# Altium schematic sheet Ethernet.SchDoc(Ethernet)
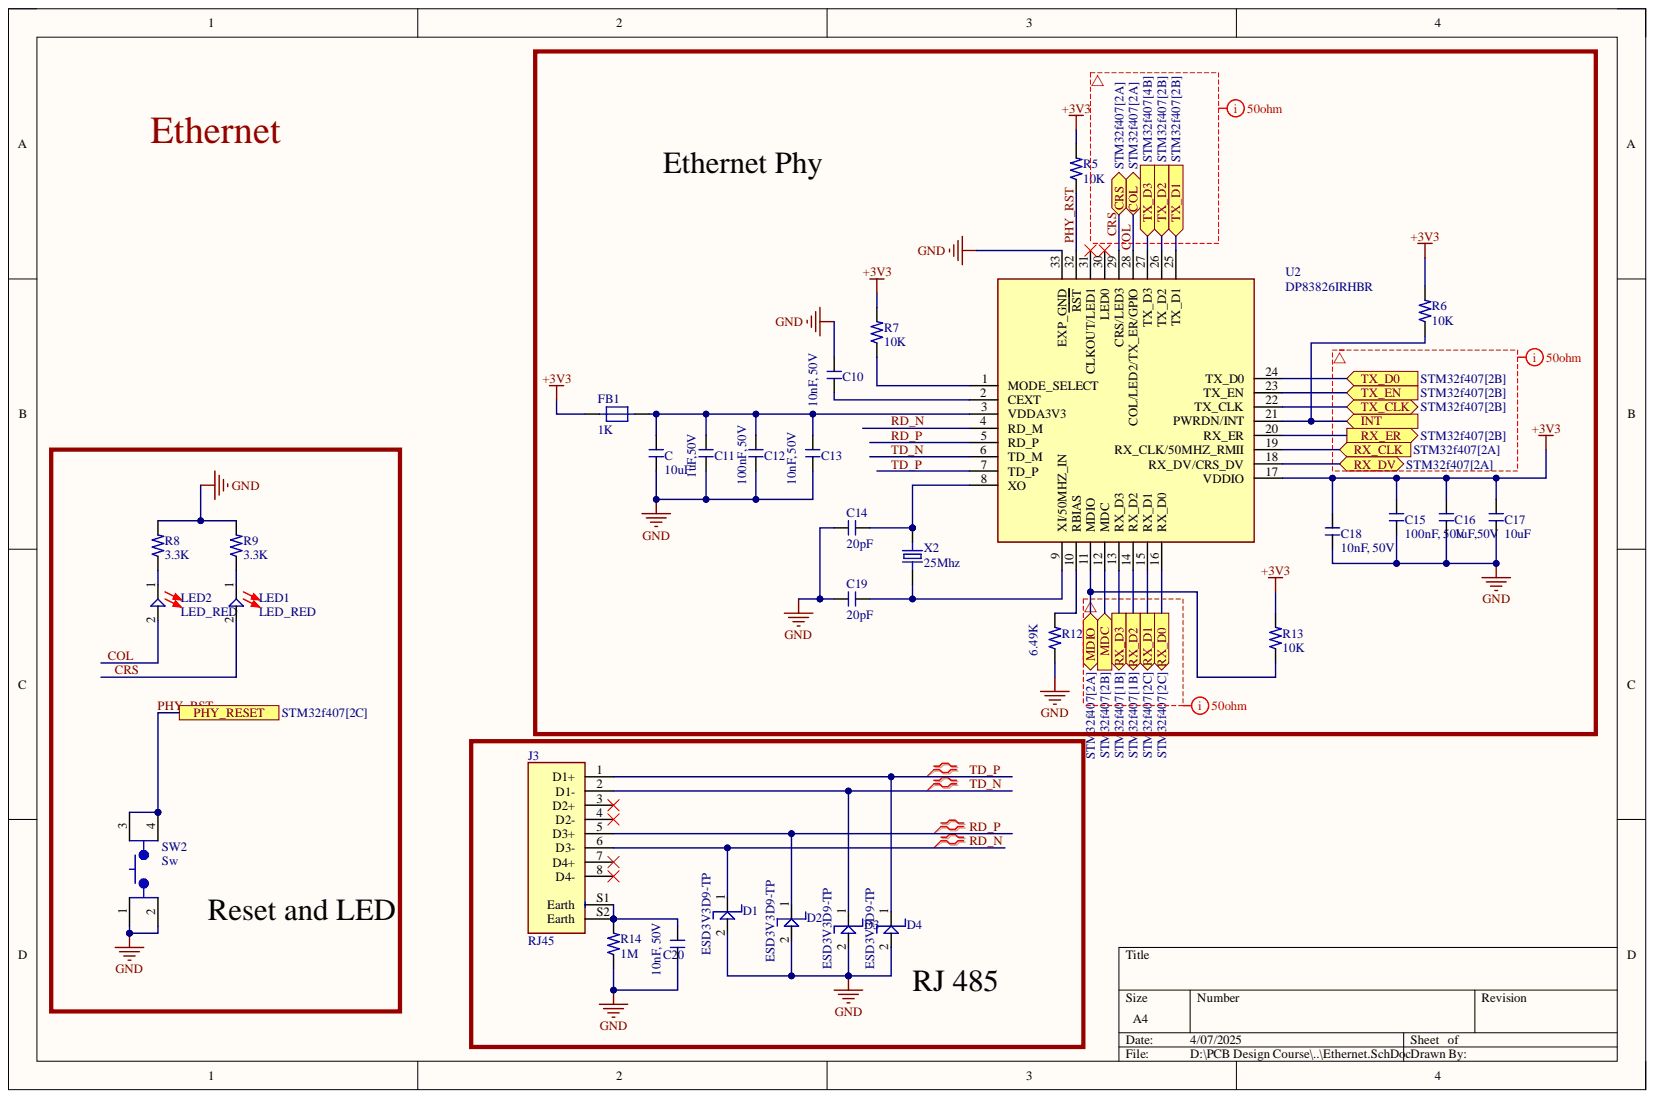
[diagram p0: Ethernet.SchDoc]

## Parts
C  10uF  [Capacitor_1206_SMD]
C10  10nF, 50V  Voltage Rating (DC): 50V  [Capacitor_0805]
C11  1uF,50V  [Capacitor_0805]
C12  100nF, 50V  Voltage: 50V  [Capacitor_0805]
C13  10nF, 50V  Voltage Rating (DC): 50V  [Capacitor_0805]
C14  20pF  [Capacitor_0805]
C15  100nF, 50V  Voltage: 50V  [Capacitor_0805]
C16  1uF,50V  Voltage Rating (DC): 50V  [Capacitor_0805]
C17  10uF  [Capacitor_1206_SMD]
C18  10nF, 50V  [Capacitor_0805]
C19  20pF  [Capacitor_0805]
C20  10nF, 50V  Voltage Rating (DC): 50V  [Capacitor_0805]
D1  ESD3V3D9-TP  [SOD_923]
D2  ESD3V3D9-TP  [SOD_923]
D3  ESD3V3D9-TP  [SOD_923]
D4  ESD3V3D9-TP  [SOD_923]
FB1  1K  [FB_0805]
J3  RJ45  [RJ45_Right_Angle_90_degree]
LED1  LED_RED  [LTST-C171KRKT]
LED2  LED_RED  [LTST-C171KRKT]
R5  10K  [Resistor_0603]
R6  10K  [Resistor_0603]
R7  10K  [Resistor_0603]
R8  3.3K  [Resistor_0603]
R9  3.3K  [Resistor_0603]
R12  6.49K  [Resistor_0805]
R13  10K  [Resistor_0603]
R14  1M  [Resistor_1206_SMD]
SW2  Sw  [SW_Tactile_SMD]
U2  DP83826IRHBR  [32-VQFN (5x5)]
X2  25Mhz  [Xtal_314_240X47_SMD]

## Ports
COL, CRS, INT, MDC, MDIO, PHY_RESET, RX_CLK, RX_D0, RX_D1, RX_D2, RX_D3, RX_DV, RX_ER, TX_CLK, TX_D0, TX_D1, TX_D2, TX_D3, TX_EN

## Nets
+3V3: C15.2 C16.2 C17.2 C18.2 FB1.1 R5.2 R6.2 R7.2 R13.2 U2.17(VDDIO)
GND: C.1 C10.2 C11.1 C12.1 C13.1 C14.1 C15.1 C16.1 C17.1 C18.1 C19.1 C20.1 D1.2 D2.2 D3.2 D4.2 R8.2 R9.2 R12.1 R14.1 SW2.1 SW2.2 U2.33(EXP_GND)
COL: LED2.2 U2.28(COL/LED2/TX_ER/GPIO) ; port COL
CRS: LED1.2 U2.29(CRS/LED3) ; port CRS
INT: R6.1 U2.21(PWRDN/INT) ; port INT
MDC: U2.12(MDC) ; port MDC
MDIO: R13.1 U2.11(MDIO) ; port MDIO
PHY_RST: R5.1 SW2.3 SW2.4 U2.32(RST) ; port PHY_RESET
RD_N: D1.1 J3.6(D3-) U2.4(RD_M)
RD_P: D2.1 J3.5(D3+) U2.5(RD_P)
RX_CLK: U2.19(RX_CLK/50MHZ_RMII) ; port RX_CLK
RX_D0: U2.16(RX_D0) ; port RX_D0
RX_D1: U2.15(RX_D1) ; port RX_D1
RX_D2: U2.14(RX_D2) ; port RX_D2
RX_D3: U2.13(RX_D3) ; port RX_D3
RX_DV: U2.18(RX_DV/CRS_DV) ; port RX_DV
RX_ER: U2.20(RX_ER) ; port RX_ER
TD_N: D3.1 J3.2(D1-) U2.6(TD_M)
TD_P: D4.1 J3.1(D1+) U2.7(TD_P)
TX_CLK: U2.22(TX_CLK) ; port TX_CLK
TX_D0: U2.24(TX_D0) ; port TX_D0
TX_D1: U2.25(TX_D1) ; port TX_D1
TX_D2: U2.26(TX_D2) ; port TX_D2
TX_D3: U2.27(TX_D3) ; port TX_D3
TX_EN: U2.23(TX_EN) ; port TX_EN
NetC10_1: C10.1 U2.2(CEXT)
NetC11_2: C.2 C11.2 C12.2 C13.2 FB1.2 U2.3(VDDA3V3)
NetC14_2: C14.2 U2.8(XO) X2.2
NetC19_2: C19.2 U2.9(XI/50MHZ_IN) X2.1
NetC20_2: C20.2 J3.S1(Earth) J3.S2(Earth) R14.2
NetLED1_1: LED1.1 R9.1
NetLED2_1: LED2.1 R8.1
NetR7_1: R7.1 U2.1(MODE_SELECT)
NetR12_2: R12.2 U2.10(RBIAS)

Not connected: J3 3(D2+) 4(D2-) 7(D4+) 8(D4-); U2 30(LED0) 31(CLKOUT/LED1)

## Library data of the parts
C C17 = Cap_10uF_1206: Multilayer Ceramic Capacitor, 10 uF, 50 V, ï¿½ 10%, X7R, 1206 [3216 Metric]; Manufacturer: Samsung; Manufacturer Part Number: CL31B106KBHNNNE; Voltage Rating: 50V; Case Code (Imperial): 1206; Case Code (Metric): 3216; Case/Package: 1206; Category: Ceramic Capacitors; Component Kind: Standard; ComponentLink1Description: https://datasheet.octopart.com/CL31B106KBHNNNE-Samsung-Electro-Mechanics-datasheet-149066874.pdf?src-supplier=Future+Electronics; ComponentLink1URL: https://datasheet.octopart.com/CL31B106KBHNNNE-Samsung-Electro-Mechanics-datasheet-149066874.pdf?src-supplier=Future+Electronics; ComponentLink2Description: http://www.samsungsem.com/kr/support/product-search/mlcc/CL31B106KBHNNNE.jsp?src-supplier=Digikey; ComponentLink2URL: http://www.samsungsem.com/kr/support/product-search/mlcc/CL31B106KBHNNNE.jsp?src-supplier=Digikey; ComponentLink3Description: https://datasheet.octopart.com/CL31B106KBHNNNE-Samsung-Electro-Mechanics-datasheet-137256395.pdf?src-supplier=Farnell; ComponentLink3URL: https://datasheet.octopart.com/CL31B106KBHNNNE-Samsung-Electro-Mechanics-datasheet-137256395.pdf?src-supplier=Farnell; ComponentLink4Description: https://datasheet.octopart.com/CL31B106KBHNNNE-Samsung-Electro-Mechanics-datasheet-110617700.pdf?src-supplier=LCSC; ComponentLink4URL: https://datasheet.octopart.com/CL31B106KBHNNNE-Samsung-Electro-Mechanics-datasheet-110617700.pdf?src-supplier=LCSC; Dielectric: X7R; Height: 1.8mm; Lead Free: Lead Free; Material: Ceramic; Max Operating Temperature: 125°C; Min Operating Temperature: -55°C; Mounting Technology: Surface Mount; Packaging: Cut Tape; Pricing: 1=0,25, 10=0,15, 100=0,1008, 1000=0,0728 (USD); RoHS: Yes; RoHS Compliant: Yes; Series: CL; Stock: 207136; Supplier: Digikey; Supplier Part Number: 1276-6767-1-ND; Tolerance: 10%; Value: 10uF; Voltage Rating (DC): 50V; Library Name: Schematics_Library_Hanif.SchLib
C10 C13 C18 C20 = Cap_10nF_0805: Multilayer Ceramic Capacitors MLCC - SMD/SMT 50V 0.01uF X7R 0805 10% AEC-Q200; Manufacturer: KEMET; Manufacturer Part Number: C0805C103K5RECAUTO; Dielectric: 1511DielectricType; Category: Ceramic Capacitors; Component Kind: Standard; ComponentLink1Description: http://www.farnell.com/datasheets/2723804.pdf?src-supplier=Farnell; ComponentLink1URL: http://www.farnell.com/datasheets/2723804.pdf?src-supplier=Farnell; ComponentLink2Description: https://api.kemet.com/component-edge/download/datasheet/C0805C103K5RECAUTO.pdf?src-supplier=Digikey; ComponentLink2URL: https://api.kemet.com/component-edge/download/datasheet/C0805C103K5RECAUTO.pdf?src-supplier=Digikey; ComponentLink3Description: http://www.farnell.com/datasheets/2723804.pdf?src-supplier=Newark; ComponentLink3URL: http://www.farnell.com/datasheets/2723804.pdf?src-supplier=Newark; Pricing: 1=0,22, 10=0,0376 (USD); RoHS: Yes; Stock: 16; Supplier: Arrow Electronics; Supplier Part Number: C0805C103K5RECAUTO; Library Name: Schematics_Library_Hanif.SchLib
  C18: Voltage Rating (DC): 50V
C11 C16 = Cap_1uF_0805: 0805 1 uF 50 V ±5 % Tolerance X7R Surface Mount Multilayer Ceramic Capacitor; Manufacturer: Yageo; Manufacturer Part Number: CC0805JKX7R9BB105; Dielectric: 1511DielectricType; Material: 4241ConductorMaterial; Category: Ceramic Capacitors; Component Kind: Standard; ComponentLink1Description: https://datasheet.octopart.com/CC0805JKX7R9BB105-Yageo-datasheet-137700082.pdf?src-supplier=Future+Electronics; ComponentLink1URL: https://datasheet.octopart.com/CC0805JKX7R9BB105-Yageo-datasheet-137700082.pdf?src-supplier=Future+Electronics; ComponentLink2Description: http://www.yageo.com/documents/recent/UPY-GPHC_X7R_6.3V-to-50V_18.pdf?src-supplier=Digikey; ComponentLink2URL: http://www.yageo.com/documents/recent/UPY-GPHC_X7R_6.3V-to-50V_18.pdf?src-supplier=Digikey; ComponentLink3Description: https://datasheet.octopart.com/CC0805JKX7R9BB105-Yageo-datasheet-151948686.pdf?src-supplier=Farnell; ComponentLink3URL: https://datasheet.octopart.com/CC0805JKX7R9BB105-Yageo-datasheet-151948686.pdf?src-supplier=Farnell; Halogen Free: 5694HalogenFree; Height: 1.45mm; Lead Free: 5697LeadFree; Pricing: 1=0,29, 10=0,168, 100=0,1077, 1000=0,07673 (USD); RoHS: Yes; Stock: 30160; Supplier: Digikey; Supplier Part Number: 311-3498-1-ND; Library Name: Schematics_Library_Hanif.SchLib
  C11: Voltage Rating (DC): 50V
C12 C15 = Cap_100nF_0805: Multilayer Ceramic Capacitor, 0.1 uF, 50 V, ï¿½ 10%, X7R, 0805 [2012 Metric]; Manufacturer: Samsung; Manufacturer Part Number: CL21B104KBCNNNC; Case Code (Imperial): 0805; Case Code (Metric): 2012; Case/Package: 0805; Category: Ceramic Capacitors; Component Kind: Standard; ComponentLink1Description: https://datasheet.ciiva.com/25710/cl21b104kbcnnnc-25710182.pdf?src-supplier=Digikey; ComponentLink1URL: https://datasheet.ciiva.com/25710/cl21b104kbcnnnc-25710182.pdf?src-supplier=Digikey; ComponentLink2Description: https://datasheet.ciiva.com/29199/454152-da-01-en-vs-kondensator-0805-29199814.pdf?src-supplier=Conrad; ComponentLink2URL: https://datasheet.ciiva.com/29199/454152-da-01-en-vs-kondensator-0805-29199814.pdf?src-supplier=Conrad; Construction: Flat; Depth: 1.25mm; Dielectric: X7R; Height: 0.85mm; Lead Free: 5697LeadFree; Length: 2mm; Material: Ceramic; Max Operating Temperature: 125°C; Min Operating Temperature: -55°C; Mounting Technology: Surface Mount; Packaging: Tape and Reel; Pricing: 1=0,08, 10=0,012, 100=0,0104, 1000=0,008 (USD); Radiation Hardening: No; RoHS: Yes; RoHS Compliant: Yes; Series: CL; Stock: 674609; Supplier: Digikey; Supplier Part Number: 1276-1003-1-ND; Thickness: 0.037inch; Tolerance: 10%; Value: 100nF; Voltage Rating: 50V; Voltage Rating (DC): 50V; Width: 0.049inch; Library Name: Schematics_Library_Hanif.SchLib
C14 C19 = Cap_20pF_0805: Cap Ceramic 20pF 50V C0G 10% SMD 0805 125°C T/R; Manufacturer: Kyocera AVX; Manufacturer Part Number: 08055A200KAT2A; Category: Ceramic Capacitors; Component Kind: Standard; ComponentLink1Description: https://datasheet.ciiva.com/204/ccog-204009.pdf?src-supplier=Digikey; ComponentLink1URL: https://datasheet.ciiva.com/204/ccog-204009.pdf?src-supplier=Digikey; ComponentLink2Description: https://datasheet.ciiva.com/11546/ccog-11546664.pdf?src-supplier=Avnet; ComponentLink2URL: https://datasheet.ciiva.com/11546/ccog-11546664.pdf?src-supplier=Avnet; Pricing: 1=0,19, 10=0,108, 100=0,0669, 1000=0,04597 (USD); RoHS: Yes; Stock: 12473; Supplier: Digikey; Supplier Part Number: 478-11887-1-ND; Library Name: Schematics_Library_Hanif.SchLib
D1-D4 = TVS DIODE 3.3V: Trans Voltage Suppressor Diode, 100W, 3.3V V(RWM), Unidirectional, 1 Element, Silicon; Manufacturer: MCC; Category: TVS Diodes; Component Kind: Standard; ComponentLink1Description: https://datasheet.ciiva.com/4479/esd3v3d9sod-923-36448-4479598.pdf?src-supplier=Mouser; ComponentLink1URL: https://datasheet.ciiva.com/4479/esd3v3d9sod-923-36448-4479598.pdf?src-supplier=Mouser; ComponentLink2Description: https://datasheet.ciiva.com/333/esd3v3d9sod-923-333173.pdf?src-supplier=Digikey; ComponentLink2URL: https://datasheet.ciiva.com/333/esd3v3d9sod-923-333173.pdf?src-supplier=Digikey; ComponentLink3Description: https://datasheet.ciiva.com/333/esd3v3d9sod-923-333174.pdf?src-supplier=Digikey; ComponentLink3URL: https://datasheet.ciiva.com/333/esd3v3d9sod-923-333174.pdf?src-supplier=Digikey; ComponentLink4Description: https://datasheet.ciiva.com/333/esd3v3d9sod-923-333175.pdf?src-supplier=Digikey; ComponentLink4URL: https://datasheet.ciiva.com/333/esd3v3d9sod-923-333175.pdf?src-supplier=Digikey; Manufacturer Part Number: ESD3V3D9-TP; Pricing: 1=0,21, 10=0,143, 100=0,067, 500=0,063, 1000=0,059, 2000=0,058 (USD); RoHS: Yes; Stock: 58922; Supplier: Digikey; Supplier Part Number: ESD3V3D9-TPMSCT-ND; Library Name: Schematics_Library_Hanif.SchLib
FB1 = FB_BLM21SP102SH1D: Ferrite Beads Multi-Layer High Current 1KOhm 25% 100MHz 1.6A 0.12Ohm DCR 0805 Automotive T/R; Manufacturer: Murata; Core Material: 228ConductorMaterial; ESR: 120mR; Value: 120mR; Category: Ferrite Beads and Chips; Component Kind: Standard; ComponentLink1Description: https://datasheet.octopart.com/BLM21SP102SH1D-Murata-datasheet-138707452.pdf?src-supplier=Future+Electronics; ComponentLink1URL: https://datasheet.octopart.com/BLM21SP102SH1D-Murata-datasheet-138707452.pdf?src-supplier=Future+Electronics; ComponentLink2Description: https://www.murata.com/en-us/api/pdfdownloadapi?cate=luNoiseSupprFilteChipFerriBead&amp;partno=BLM21SP102SH1%23&src-supplier=Digikey; ComponentLink2URL: https://www.murata.com/en-us/api/pdfdownloadapi?cate=luNoiseSupprFilteChipFerriBead&amp;partno=BLM21SP102SH1%23&src-supplier=Digikey; ComponentLink3Description: https://datasheet.octopart.com/BLM21SP102SH1D-Murata-datasheet-166171483.pdf?src-supplier=TME; ComponentLink3URL: https://datasheet.octopart.com/BLM21SP102SH1D-Murata-datasheet-166171483.pdf?src-supplier=TME; Height: 1.05mm; Manufacturer Part Number: BLM21SP102SH1D; Pricing: 1=0,33, 10=0,179, 50=0,179, 100=0,159, 1000=0,105, 10000=0,083 (USD); RMS Current (Irms): 1.6A; RoHS: Yes; Stock: 4751; Supplier: Mouser; Supplier Part Number: 81-BLM21SP102SH1D; Test Frequency: 100MHz; Tolerance: 25%; Library Name: Schematics_Library_Hanif.SchLib
J3 = CON_LAN_RJ45: Conn RJ-45 F 8 POS 2.54mm Solder RA Thru-Hole 8 Terminal 1 Port; Manufacturer: Assmann; Manufacturer Part Number: A-2004-2-4-LPS-N-R; Category: Modular / Ethernet Connectors; Component Kind: Standard; ComponentLink1Description: https://datasheet.ciiva.com/30247/a-2004-2-4-lps-n-r-30247832.pdf?src-supplier=Onlinecomponents.com; ComponentLink1URL: https://datasheet.ciiva.com/30247/a-2004-2-4-lps-n-r-30247832.pdf?src-supplier=Onlinecomponents.com; ComponentLink2Description: https://datasheet.ciiva.com/685/a-2004-2-4-lps-n-r-685830.pdf?src-supplier=Digikey; ComponentLink2URL: https://datasheet.ciiva.com/685/a-2004-2-4-lps-n-r-685830.pdf?src-supplier=Digikey; Pricing: 1=1,39, 10=1,178, 25=1,1036, 50=1,0508, 100=1,0006, 300=0,92593, 500=0,89314, 1000=0,85049, 2500=0,79726 (USD); RoHS: Yes; Stock: 16450; Supplier: Digikey; Supplier Part Number: AE10387-ND; Library Name: Schematics_Library_Hanif.SchLib
LED1 LED2 = LED_RED_SMD: OPTOELECTRONICS - SMD Chip LED - TopView Single Color Chip LED, Red, 631 at 20mA, 18 to approx. 180 at 20mA; Manufacturer: Vishay Lite-On; Wavelength: 631nm; Category: LEDs; Component Kind: Standard; ComponentLink1Description: https://datasheet.ciiva.com/11775/0900766b80dc0299-11775643.pdf?src-supplier=Rs; ComponentLink1URL: https://datasheet.ciiva.com/11775/0900766b80dc0299-11775643.pdf?src-supplier=Rs; ComponentLink2Description: https://datasheet.ciiva.com/12403/lite-on-ltst-c171krkt-187201-12403781.pdf?src-supplier=Mouser; ComponentLink2URL: https://datasheet.ciiva.com/12403/lite-on-ltst-c171krkt-187201-12403781.pdf?src-supplier=Mouser; ComponentLink3Description: https://datasheet.ciiva.com/16444/lite-on20ltst-c171krkt-16444779.pdf?src-supplier=Future+Electronics; ComponentLink3URL: https://datasheet.ciiva.com/16444/lite-on20ltst-c171krkt-16444779.pdf?src-supplier=Future+Electronics; ComponentLink4Description: https://datasheet.ciiva.com/2110/s-110-ltst-c171krkt-2110066.pdf?src-supplier=Digikey; ComponentLink4URL: https://datasheet.ciiva.com/2110/s-110-ltst-c171krkt-2110066.pdf?src-supplier=Digikey; ComponentLink5Description: https://datasheet.ciiva.com/2110/s-110-ltst-c171krkt-2110067.pdf?src-supplier=Digikey; ComponentLink5URL: https://datasheet.ciiva.com/2110/s-110-ltst-c171krkt-2110067.pdf?src-supplier=Digikey; ComponentLink6Description: https://datasheet.ciiva.com/2110/s-110-ltst-c171krkt-2110068.pdf?src-supplier=Digikey; ComponentLink6URL: https://datasheet.ciiva.com/2110/s-110-ltst-c171krkt-2110068.pdf?src-supplier=Digikey; Lead Free: 5697LeadFree; Manufacturer Part Number: LTST-C171KRKT; Pricing: 1=0,15, 10=0,105, 100=0,0723, 500=0,05736, 1000=0,05238 (USD); RoHS: Yes; Stock: 98881; Supplier: Digikey; Supplier Part Number: 160-1427-1-ND; Library Name: Schematics_Library_Hanif.SchLib
R5-R7 R13 = R_10K_0603: SMD Chip Resistor, 10 kOhm, ï¿½ 1%, 100 mW, 0603 [1608 Metric], Thick Film, General Purpose; Manufacturer: Yageo; Manufacturer Part Number: RC0603FR-0710KL; Max Power Dissipation: 100mW; Temperature Coefficient: 100ppm/°C; Value: 10kR; Voltage Rating: 75V; Voltage Rating (DC): 50V; Case Code (Imperial): 0603; Case Code (Metric): 1608; Case/Package: 0603; Category: Chip SMD Resistors; Component Kind: Standard; ComponentLink1Description: https://datasheet.ciiva.com/7660/38590-7660789.pdf?src-supplier=Element14; ComponentLink1URL: https://datasheet.ciiva.com/7660/38590-7660789.pdf?src-supplier=Element14; ComponentLink2Description: https://datasheet.ciiva.com/7983/443971-7983596.pdf?src-supplier=Element14; ComponentLink2URL: https://datasheet.ciiva.com/7983/443971-7983596.pdf?src-supplier=Element14; ComponentLink3Description: https://datasheet.ciiva.com/22747/443971-22747519.pdf?src-supplier=Element14; ComponentLink3URL: https://datasheet.ciiva.com/22747/443971-22747519.pdf?src-supplier=Element14; ComponentLink4Description: https://datasheet.ciiva.com/46440/1868787-46440891.pdf?src-supplier=Element14; ComponentLink4URL: https://datasheet.ciiva.com/46440/1868787-46440891.pdf?src-supplier=Element14; ComponentLink5Description: https://datasheet.ciiva.com/7746/1738695-7746239.pdf?src-supplier=Element14; ComponentLink5URL: https://datasheet.ciiva.com/7746/1738695-7746239.pdf?src-supplier=Element14; ComponentLink6Description: https://datasheet.ciiva.com/15189/getdatasheetpartid-409289-15189591.pdf?src-supplier=Verical; ComponentLink6URL: https://datasheet.ciiva.com/15189/getdatasheetpartid-409289-15189591.pdf?src-supplier=Verical; Construction: Rectangular; Depth: 0.8mm; Features: Moisture Resistant; Height: 0.45mm; Lead Free: 5697LeadFree; Lead Pitch: 0.3mm; Length: 1.6mm; Max Operating Temperature: 155°C; Military Standard: Not; Min Operating Temperature: -55°C; Number of Terminations: 2; Packaging: Tape and Reel; Pins: 2; Power Rating: 100mW; Pricing: 1=0,1, 10=0,009, 100=0,0071, 1000=0,00612 (USD); Reach SVHC Compliant: No; Resistance Tolerance: 1%; Resistor Type: Thick Film; RoHS: Yes; RoHS Compliant: Yes; Series: RC; Stock: 2808060; Supplier: Digikey; Supplier Part Number: 311-10.0KHRCT-ND; Termination: SMD/SMT; Tolerance: 1%; Weight: 7.1E-05oz; Width: 0.8mm; Library Name: Schematics_Library_Hanif.SchLib
R8 R9 = R_3.3K_0603: Res Thick Film 0603 3.3K Ohm 1% 0.1W(1/10W) ±100ppm/C Pad SMD T/R; Manufacturer: Walsin Technologies; Manufacturer Part Number: WR06X3301FTL; Power Rating: 100mW; Temperature Coefficient: 100ppm/°C; Value: 3.3kR; Voltage Rating: 75V; Case Code (Imperial): 0603; Case Code (Metric): 1608; Case/Package: 0603; Category: Chip SMD Resistors; Component Kind: Standard; ComponentLink1Description: http://www.farnell.com/datasheets/1960319.pdf?src-supplier=Farnell; ComponentLink1URL: http://www.farnell.com/datasheets/1960319.pdf?src-supplier=Farnell; ComponentLink2Description: https://datasheet.octopart.com/WR06X3301FTL-Walsin-Technologies-datasheet-48038251.pdf?src-supplier=Element14; ComponentLink2URL: https://datasheet.octopart.com/WR06X3301FTL-Walsin-Technologies-datasheet-48038251.pdf?src-supplier=Element14; ComponentLink3Description: http://www.farnell.com/datasheets/1960319.pdf?src-supplier=Element14; ComponentLink3URL: http://www.farnell.com/datasheets/1960319.pdf?src-supplier=Element14; ComponentLink4Description: https://datasheet.octopart.com/WR06X3301FTL-Walsin-Technologies-datasheet-32780790.pdf?src-supplier=Future+Electronics; ComponentLink4URL: https://datasheet.octopart.com/WR06X3301FTL-Walsin-Technologies-datasheet-32780790.pdf?src-supplier=Future+Electronics; Contact Material: 154ConductorMaterial; Lead Free: 5697LeadFree; Mounting Technology: Surface Mount; Packaging: Tape and Reel; Pricing: 1=0,1, 10=0,015, 50=0,0086, 100=0,0068, 500=0,00418, 1000=0,00345 (USD); Reach SVHC Compliant: Unknown; Resistance Tolerance: 1%; Resistor Type: Thick Film; RoHS: Yes; RoHS Compliant: Yes; Stock: 24599; Supplier: Digikey; Supplier Part Number: 1292-WR06X3301FTLCT-ND; Voltage Rating (DC): 75V; Library Name: Schematics_Library_Hanif.SchLib
R12 = R_6.49K_0805: SMD Chip Resistor, 6.49 kOhm, ï¿½ 1%, 125 mW, 0805 [2012 Metric], Thick Film, General Purpose; Manufacturer: Yageo; Manufacturer Part Number: RC0805FR-076K49L; Category: Chip SMD Resistors; Component Kind: Standard; ComponentLink1Description: https://datasheet.ciiva.com/2519/pyu-r-int-thick-7-2519753.pdf?src-supplier=Digikey; ComponentLink1URL: https://datasheet.ciiva.com/2519/pyu-r-int-thick-7-2519753.pdf?src-supplier=Digikey; ComponentLink2Description: https://datasheet.ciiva.com/2519/pyu-r-int-thick-7-2519754.pdf?src-supplier=Digikey; ComponentLink2URL: https://datasheet.ciiva.com/2519/pyu-r-int-thick-7-2519754.pdf?src-supplier=Digikey; ComponentLink3Description: https://datasheet.ciiva.com/2519/pyu-r-int-thick-7-2519755.pdf?src-supplier=Digikey; ComponentLink3URL: https://datasheet.ciiva.com/2519/pyu-r-int-thick-7-2519755.pdf?src-supplier=Digikey; ComponentLink4Description: https://datasheet.ciiva.com/17045/rc0805-17045354.pdf?src-supplier=Future+Electronics; ComponentLink4URL: https://datasheet.ciiva.com/17045/rc0805-17045354.pdf?src-supplier=Future+Electronics; Lead Free: 5697LeadFree; Pricing: 1=0,1, 10=0,011, 100=0,0082, 1000=0,00714 (USD); RoHS: Yes; Stock: 48332; Supplier: Digikey; Supplier Part Number: 311-6.49KCRCT-ND; Library Name: Schematics_Library_Hanif.SchLib
R14 = R_1M_1206: Res Thick Film 1206 1M Ohm 1% 0.25W(1/4W) ±100ppm/C Molded SMD Paper T/R; Manufacturer: Walsin Technologies; Manufacturer Part Number: WR12X1004FTL; Power Rating: 250mW; Temperature Coefficient: 100ppm/°C; Value: 1MR; Voltage Rating: 200V; Case Code (Imperial): 1206; Case Code (Metric): 3216; Case/Package: Molded; Category: Chip SMD Resistors; Component Kind: Standard; ComponentLink1Description: http://www.farnell.com/datasheets/1960319.pdf?src-supplier=Farnell; ComponentLink1URL: http://www.farnell.com/datasheets/1960319.pdf?src-supplier=Farnell; ComponentLink2Description: https://www.verical.com/server-webapp/html/getDatasheet?partID=137179&src-supplier=Verical; ComponentLink2URL: https://www.verical.com/server-webapp/html/getDatasheet?partID=137179&src-supplier=Verical; ComponentLink3Description: https://datasheet.octopart.com/WR12X1004FTL-Walsin-Technologies-datasheet-48038251.pdf?src-supplier=Element14; ComponentLink3URL: https://datasheet.octopart.com/WR12X1004FTL-Walsin-Technologies-datasheet-48038251.pdf?src-supplier=Element14; Construction: Rectangular; Contact Material: 154ConductorMaterial; Depth: 1.6mm; Lead Free: 5697LeadFree; Length: 3.1mm; Max Operating Temperature: 155°C; Military Standard: Not; Min Operating Temperature: -55°C; Mounting Technology: Surface Mount; Packaging: Tape and Reel; Pricing: 1=0,1, 10=0,03, 50=0,0178, 100=0,0146, 500=0,00964, 1000=0,00821 (USD); Reach SVHC Compliant: Unknown; Resistance Tolerance: 1%; Resistor Type: Thick Film; RoHS: Yes; RoHS Compliant: Yes; Stock: 25189; Supplier: Digikey; Supplier Part Number: 1292-WR12X1004FTLCT-ND; Tolerance: 1%; Voltage Rating (DC): 200V; Library Name: Schematics_Library_Hanif.SchLib
SW2 = SW_Tactile_SPST: TL3305A Series SPST 12 V 4.5 x 4.5 mm 260 gf Top Actuated SMT Tactile Switch; Manufacturer: E-Switch; Manufacturer Part Number: TL3305AF260QG; Actuator Type: Standard; Illumination Type: Non-Illuminated; Mechanical Life: 200000Cycles; Operating Force: 260gf; Switch Function: Off-Mom; Switch Travel: 0.2mm; Category: Tactile Switches; Component Kind: Standard; ComponentLink1Description: http://www.farnell.com/datasheets/2554191.pdf?src-supplier=Farnell; ComponentLink1URL: http://www.farnell.com/datasheets/2554191.pdf?src-supplier=Farnell; ComponentLink2Description: http://www.farnell.com/datasheets/2554191.pdf?src-supplier=Newark; ComponentLink2URL: http://www.farnell.com/datasheets/2554191.pdf?src-supplier=Newark; ComponentLink3Description: http://spec_sheets.e-switch.com/specs/P010471.pdf?src-supplier=Mouser; ComponentLink3URL: http://spec_sheets.e-switch.com/specs/P010471.pdf?src-supplier=Mouser; ComponentLink4Description: http://www.farnell.com/datasheets/2554191.pdf?src-supplier=Element14; ComponentLink4URL: http://www.farnell.com/datasheets/2554191.pdf?src-supplier=Element14; ComponentLink5Description: http://spec_sheets.e-switch.com/specs/P010471.pdf?src-supplier=Digikey; ComponentLink5URL: http://spec_sheets.e-switch.com/specs/P010471.pdf?src-supplier=Digikey; ComponentLink6Description: http://spec_sheets.e-switch.com/specs/P010471.pdf?src-supplier=NAC+Semi; ComponentLink6URL: http://spec_sheets.e-switch.com/specs/P010471.pdf?src-supplier=NAC+Semi; Lead Free: Lead Free; Max Operating Temperature: 70°C; Min Operating Temperature: -20°C; Mounting Technology: Surface Mount; Packaging: Cut Tape; Pricing: 1=0,2, 10=0,176, 25=0,1664, 100=0,153, 250=0,14456, 500=0,1385, 1000=0,13264 (USD); RoHS: No; Series: TL3305; Stock: 97166; Supplier: Digikey; Supplier Part Number: EG5353CT-ND; Termination: Gull Wing; Throw Configuration: SPST; Library Name: Schematics_Library_Hanif.SchLib
U2 = IC_DP83826IRHBR: Ethernet PHY 10BASE-Te/00BASE-TX 10/100 Mbps 160mW 1.8V/3.3V 2-Pin VQFN Industrial; Manufacturer: Texas Instruments; Interface: 1515Interface; Max Supply Voltage: 3.6V; Min Supply Voltage: 1.62V; Number of Channels: 1NUMBER; Category: Ethernet Interface ICs; Component Kind: Standard; Data Rate: 100Mbps; Height: 1mm; Length: 5mm; Manufacturer Part Number: DP83826IRHBR; Pins: 32NUMBER; Pricing: 1=1,95, 10=1,44, 50=1,32, 100=1,17, 1000=1,03, 10000=0,986 (USD); RoHS: Yes; Stock: 7439; Supplier: Mouser; Supplier Part Number: 595-DP83826IRHBR; Thickness: 900um; Width: 5mm; Library Name: Schematics_Library_Hanif.SchLib
X2 = Xtal_25MHz: Crystal 25MHz ±30ppm (Tol) ±50ppm (Stability) 20pF 2-Pin HC-49/SDLF SMD T/R; Manufacturer: Fox Electronics; Manufacturer Part Number: FC4SDCBMF25.0-T1; Component Kind: Standard; ComponentLink1Description: http://datasheet.octopart.com/FC4SDCBMF25.0-T1-Fox-Electronics-datasheet-136840669.pdf?src-supplier=Avnet; ComponentLink1URL: http://datasheet.octopart.com/FC4SDCBMF25.0-T1-Fox-Electronics-datasheet-136840669.pdf?src-supplier=Avnet; ComponentLink2Description: http://www.foxonline.com/pdfs/C4SD.pdf?src-supplier=Digikey; ComponentLink2URL: http://www.foxonline.com/pdfs/C4SD.pdf?src-supplier=Digikey; ComponentLink3Description: http://datasheet.octopart.com/FC4SDCBMF25.0-T1-Fox-Electronics-datasheet-136840669.pdf?src-supplier=Digikey; ComponentLink3URL: http://datasheet.octopart.com/FC4SDCBMF25.0-T1-Fox-Electronics-datasheet-136840669.pdf?src-supplier=Digikey; ComponentLink4Description: http://datasheet.octopart.com/FC4SDCBMF25.0-T1-Fox-Electronics-datasheet-136840669.pdf?src-supplier=Onlinecomponents.com; ComponentLink4URL: http://datasheet.octopart.com/FC4SDCBMF25.0-T1-Fox-Electronics-datasheet-136840669.pdf?src-supplier=Onlinecomponents.com; ComponentLink5Description: http://datasheet.octopart.com/FC4SDCBMF25.0-T1-Fox-Electronics-datasheet-136840669.pdf?src-supplier=Verical; ComponentLink5URL: http://datasheet.octopart.com/FC4SDCBMF25.0-T1-Fox-Electronics-datasheet-136840669.pdf?src-supplier=Verical; ComponentLink6Description: https://datasheet.octopart.com/FC4SDCBMF25.0-T1-Fox-Electronics-datasheet-136840669.pdf?src-supplier=Future+Electronics; ComponentLink6URL: https://datasheet.octopart.com/FC4SDCBMF25.0-T1-Fox-Electronics-datasheet-136840669.pdf?src-supplier=Future+Electronics; ComponentLink7Description: http://datasheet.octopart.com/FC4SDCBMF25.0-T1-Fox-Electronics-datasheet-136840669.pdf?src-supplier=NAC+Semi; ComponentLink7URL: http://datasheet.octopart.com/FC4SDCBMF25.0-T1-Fox-Electronics-datasheet-136840669.pdf?src-supplier=NAC+Semi; ComponentLink8Description: http://datasheet.octopart.com/FC4SDCBMF25.0-T1-Fox-Electronics-datasheet-136840669.pdf?src-supplier=Electro+Sonic; ComponentLink8URL: http://datasheet.octopart.com/FC4SDCBMF25.0-T1-Fox-Electronics-datasheet-136840669.pdf?src-supplier=Electro+Sonic; Pricing: 1=0,24, 10=0,208, 50=0,1888, 100=0,181, 500=0,16406 (USD); RoHS: Yes; Stock: 31979; Supplier: Digikey; Supplier Part Number: 631-FC4SDCBMF25.0-T1CT-ND; Library Name: Schematics_Library_Hanif.SchLib
